# Altium schematic sheet 06_PWR.SchDoc(06_PWR) (continued)

## Library data of the parts
C2 = CAPACITOR: Generic Capacitor; Value: 47nF; Component Kind: Standard; Library Name: Digilent.IntLib
C3 C36 = CAP1_Dup1: CURRENT: CIMAX; DIST: FLAT; KNEE: CBMAX; MAX_TEMP: CTMAX; PSpiceTemplate: C^@REFDES %1 %2 ?TOLERANCE|C^@REFDES| @VALUE ?IC/IC=@IC/ TC=@TC1,@TC2 ?TOLERANCE|\n.model C^@REFDES CAP C=1 DEV=@TOLERANCE% TC1=@TC1 TC2=@TC2 VC1=@VC1 VC2=@VC2|; REUSE_ANNOTATE: TRUE; REUSE_ID: 103; SLOPE: CSMAX; TC1: 0; VOLTAGE: CMAX; Component Kind: Standard; Simulation: CAP1; TC2: 0; VC1: 0; VC2: 0
  C3: Value: 10uF
  C36: Value: 100uF
C5 = CAP1_Dup1: CURRENT: CIMAX; DIST: FLAT; KNEE: CBMAX; MAX_TEMP: CTMAX; PSpiceTemplate: C^@REFDES %1 %2 ?TOLERANCE|C^@REFDES| @VALUE ?IC/IC=@IC/ TC=@TC1,@TC2 ?TOLERANCE|\n.model C^@REFDES CAP C=1 DEV=@TOLERANCE% TC1=@TC1 TC2=@TC2 VC1=@VC1 VC2=@VC2|; REUSE_ANNOTATE: TRUE; REUSE_ID: 343; SLOPE: CSMAX; TC1: 0; VOLTAGE: CMAX; Component Kind: Standard; Simulation: CAP1; TC2: 0; Value: 100nF; VC1: 0; VC2: 0
C6 = CAP1_Dup1: CURRENT: CIMAX; DIST: FLAT; KNEE: CBMAX; MAX_TEMP: CTMAX; PSpiceTemplate: C^@REFDES %1 %2 ?TOLERANCE|C^@REFDES| @VALUE ?IC/IC=@IC/ TC=@TC1,@TC2 ?TOLERANCE|\n.model C^@REFDES CAP C=1 DEV=@TOLERANCE% TC1=@TC1 TC2=@TC2 VC1=@VC1 VC2=@VC2|; REUSE_ANNOTATE: TRUE; REUSE_ID: 344; SLOPE: CSMAX; TC1: 0; VOLTAGE: CMAX; Component Kind: Standard; Simulation: CAP1; TC2: 0; Value: 100nF; VC1: 0; VC2: 0
C7 = CAP1_Dup1: CURRENT: CIMAX; DIST: FLAT; KNEE: CBMAX; MAX_TEMP: CTMAX; PSpiceTemplate: C^@REFDES %1 %2 ?TOLERANCE|C^@REFDES| @VALUE ?IC/IC=@IC/ TC=@TC1,@TC2 ?TOLERANCE|\n.model C^@REFDES CAP C=1 DEV=@TOLERANCE% TC1=@TC1 TC2=@TC2 VC1=@VC1 VC2=@VC2|; REUSE_ANNOTATE: TRUE; REUSE_ID: 106; SLOPE: CSMAX; TC1: 0; VOLTAGE: CMAX; Component Kind: Standard; Simulation: CAP1; TC2: 0; Value: 100nF; VC1: 0; VC2: 0
C8 = CAP1_Dup1: CURRENT: CIMAX; DIST: FLAT; KNEE: CBMAX; MAX_TEMP: CTMAX; PSpiceTemplate: C^@REFDES %1 %2 ?TOLERANCE|C^@REFDES| @VALUE ?IC/IC=@IC/ TC=@TC1,@TC2 ?TOLERANCE|\n.model C^@REFDES CAP C=1 DEV=@TOLERANCE% TC1=@TC1 TC2=@TC2 VC1=@VC1 VC2=@VC2|; REUSE_ANNOTATE: TRUE; REUSE_ID: 107; SLOPE: CSMAX; TC1: 0; VOLTAGE: CMAX; Component Kind: Standard; Simulation: CAP1; TC2: 0; Value: 100nF; VC1: 0; VC2: 0
C9 = CAP1_Dup1: CURRENT: CIMAX; DIST: FLAT; KNEE: CBMAX; MAX_TEMP: CTMAX; PSpiceTemplate: C^@REFDES %1 %2 ?TOLERANCE|C^@REFDES| @VALUE ?IC/IC=@IC/ TC=@TC1,@TC2 ?TOLERANCE|\n.model C^@REFDES CAP C=1 DEV=@TOLERANCE% TC1=@TC1 TC2=@TC2 VC1=@VC1 VC2=@VC2|; REUSE_ANNOTATE: TRUE; REUSE_ID: 108; SLOPE: CSMAX; TC1: 0; VOLTAGE: CMAX; Component Kind: Standard; Simulation: CAP1; TC2: 0; Value: 100nF; VC1: 0; VC2: 0
C10 = CAP1_Dup1: CURRENT: CIMAX; DIST: FLAT; KNEE: CBMAX; MAX_TEMP: CTMAX; PSpiceTemplate: C^@REFDES %1 %2 ?TOLERANCE|C^@REFDES| @VALUE ?IC/IC=@IC/ TC=@TC1,@TC2 ?TOLERANCE|\n.model C^@REFDES CAP C=1 DEV=@TOLERANCE% TC1=@TC1 TC2=@TC2 VC1=@VC1 VC2=@VC2|; REUSE_ANNOTATE: TRUE; REUSE_ID: 109; SLOPE: CSMAX; TC1: 0; VOLTAGE: CMAX; Component Kind: Standard; Simulation: CAP1; TC2: 0; Value: 100nF; VC1: 0; VC2: 0
C11 = CAP1_Dup1: CURRENT: CIMAX; DIST: FLAT; KNEE: CBMAX; MAX_TEMP: CTMAX; PSpiceTemplate: C^@REFDES %1 %2 ?TOLERANCE|C^@REFDES| @VALUE ?IC/IC=@IC/ TC=@TC1,@TC2 ?TOLERANCE|\n.model C^@REFDES CAP C=1 DEV=@TOLERANCE% TC1=@TC1 TC2=@TC2 VC1=@VC1 VC2=@VC2|; REUSE_ANNOTATE: TRUE; REUSE_ID: 111; SLOPE: CSMAX; TC1: 0; VOLTAGE: CMAX; Component Kind: Standard; Simulation: CAP1; TC2: 0; Value: 100nF; VC1: 0; VC2: 0
C12 = CAP1_Dup1: CURRENT: CIMAX; DIST: FLAT; KNEE: CBMAX; MAX_TEMP: CTMAX; PSpiceTemplate: C^@REFDES %1 %2 ?TOLERANCE|C^@REFDES| @VALUE ?IC/IC=@IC/ TC=@TC1,@TC2 ?TOLERANCE|\n.model C^@REFDES CAP C=1 DEV=@TOLERANCE% TC1=@TC1 TC2=@TC2 VC1=@VC1 VC2=@VC2|; REUSE_ANNOTATE: TRUE; REUSE_ID: 112; SLOPE: CSMAX; TC1: 0; VOLTAGE: CMAX; Component Kind: Standard; Simulation: CAP1; TC2: 0; Value: 100nF; VC1: 0; VC2: 0
C13 = CAP1_Dup1: CURRENT: CIMAX; DIST: FLAT; KNEE: CBMAX; MAX_TEMP: CTMAX; PSpiceTemplate: C^@REFDES %1 %2 ?TOLERANCE|C^@REFDES| @VALUE ?IC/IC=@IC/ TC=@TC1,@TC2 ?TOLERANCE|\n.model C^@REFDES CAP C=1 DEV=@TOLERANCE% TC1=@TC1 TC2=@TC2 VC1=@VC1 VC2=@VC2|; REUSE_ANNOTATE: TRUE; REUSE_ID: 110; SLOPE: CSMAX; TC1: 0; VOLTAGE: CMAX; Component Kind: Standard; Simulation: CAP1; TC2: 0; Value: 100nF; VC1: 0; VC2: 0
C14 = CAP1_Dup1: CURRENT: CIMAX; DIST: FLAT; KNEE: CBMAX; MAX_TEMP: CTMAX; PSpiceTemplate: C^@REFDES %1 %2 ?TOLERANCE|C^@REFDES| @VALUE ?IC/IC=@IC/ TC=@TC1,@TC2 ?TOLERANCE|\n.model C^@REFDES CAP C=1 DEV=@TOLERANCE% TC1=@TC1 TC2=@TC2 VC1=@VC1 VC2=@VC2|; REUSE_ANNOTATE: TRUE; REUSE_ID: 113; SLOPE: CSMAX; TC1: 0; VOLTAGE: CMAX; Component Kind: Standard; Simulation: CAP1; TC2: 0; Value: 100nF; VC1: 0; VC2: 0
C15 = CAP1_Dup1: CURRENT: CIMAX; DIST: FLAT; KNEE: CBMAX; MAX_TEMP: CTMAX; PSpiceTemplate: C^@REFDES %1 %2 ?TOLERANCE|C^@REFDES| @VALUE ?IC/IC=@IC/ TC=@TC1,@TC2 ?TOLERANCE|\n.model C^@REFDES CAP C=1 DEV=@TOLERANCE% TC1=@TC1 TC2=@TC2 VC1=@VC1 VC2=@VC2|; REUSE_ANNOTATE: TRUE; REUSE_ID: 340; SLOPE: CSMAX; TC1: 0; VOLTAGE: CMAX; Component Kind: Standard; Simulation: CAP1; TC2: 0; Value: 100nF; VC1: 0; VC2: 0
C16 = CAP1_Dup1: CURRENT: CIMAX; DIST: FLAT; KNEE: CBMAX; MAX_TEMP: CTMAX; PSpiceTemplate: C^@REFDES %1 %2 ?TOLERANCE|C^@REFDES| @VALUE ?IC/IC=@IC/ TC=@TC1,@TC2 ?TOLERANCE|\n.model C^@REFDES CAP C=1 DEV=@TOLERANCE% TC1=@TC1 TC2=@TC2 VC1=@VC1 VC2=@VC2|; REUSE_ANNOTATE: TRUE; REUSE_ID: 341; SLOPE: CSMAX; TC1: 0; VOLTAGE: CMAX; Component Kind: Standard; Simulation: CAP1; TC2: 0; Value: 100nF; VC1: 0; VC2: 0
C18 = CAP1_Dup1: CURRENT: CIMAX; DIST: FLAT; KNEE: CBMAX; MAX_TEMP: CTMAX; PSpiceTemplate: C^@REFDES %1 %2 ?TOLERANCE|C^@REFDES| @VALUE ?IC/IC=@IC/ TC=@TC1,@TC2 ?TOLERANCE|\n.model C^@REFDES CAP C=1 DEV=@TOLERANCE% TC1=@TC1 TC2=@TC2 VC1=@VC1 VC2=@VC2|; REUSE_ANNOTATE: TRUE; REUSE_ID: 342; SLOPE: CSMAX; TC1: 0; VOLTAGE: CMAX; Component Kind: Standard; Simulation: CAP1; TC2: 0; Value: 100nF; VC1: 0; VC2: 0
C19 C150 C151 = CAP1_Dup1: CURRENT: CIMAX; DIST: FLAT; KNEE: CBMAX; MAX_TEMP: CTMAX; PSpiceTemplate: C^@REFDES %1 %2 ?TOLERANCE|C^@REFDES| @VALUE ?IC/IC=@IC/ TC=@TC1,@TC2 ?TOLERANCE|\n.model C^@REFDES CAP C=1 DEV=@TOLERANCE% TC1=@TC1 TC2=@TC2 VC1=@VC1 VC2=@VC2|; REUSE_ANNOTATE: TRUE; REUSE_ID: 339; SLOPE: CSMAX; TC1: 0; VOLTAGE: CMAX; Component Kind: Standard; Simulation: CAP1; TC2: 0; Value: 100nF; VC1: 0; VC2: 0
C20 = CAP1_Dup1: CURRENT: CIMAX; DIST: FLAT; KNEE: CBMAX; MAX_TEMP: CTMAX; PSpiceTemplate: C^@REFDES %1 %2 ?TOLERANCE|C^@REFDES| @VALUE ?IC/IC=@IC/ TC=@TC1,@TC2 ?TOLERANCE|\n.model C^@REFDES CAP C=1 DEV=@TOLERANCE% TC1=@TC1 TC2=@TC2 VC1=@VC1 VC2=@VC2|; REUSE_ANNOTATE: TRUE; REUSE_ID: 116; SLOPE: CSMAX; TC1: 0; VOLTAGE: CMAX; Component Kind: Standard; Simulation: CAP1; TC2: 0; Value: 100nF; VC1: 0; VC2: 0
C21 = CAP1_Dup1: CURRENT: CIMAX; DIST: FLAT; KNEE: CBMAX; MAX_TEMP: CTMAX; PSpiceTemplate: C^@REFDES %1 %2 ?TOLERANCE|C^@REFDES| @VALUE ?IC/IC=@IC/ TC=@TC1,@TC2 ?TOLERANCE|\n.model C^@REFDES CAP C=1 DEV=@TOLERANCE% TC1=@TC1 TC2=@TC2 VC1=@VC1 VC2=@VC2|; REUSE_ANNOTATE: TRUE; REUSE_ID: 119; SLOPE: CSMAX; TC1: 0; VOLTAGE: CMAX; Component Kind: Standard; Simulation: CAP1; TC2: 0; Value: 100nF; VC1: 0; VC2: 0
C22 = CAP1_Dup1: CURRENT: CIMAX; DIST: FLAT; KNEE: CBMAX; MAX_TEMP: CTMAX; PSpiceTemplate: C^@REFDES %1 %2 ?TOLERANCE|C^@REFDES| @VALUE ?IC/IC=@IC/ TC=@TC1,@TC2 ?TOLERANCE|\n.model C^@REFDES CAP C=1 DEV=@TOLERANCE% TC1=@TC1 TC2=@TC2 VC1=@VC1 VC2=@VC2|; REUSE_ANNOTATE: TRUE; REUSE_ID: 122; SLOPE: CSMAX; TC1: 0; VOLTAGE: CMAX; Component Kind: Standard; Simulation: CAP1; TC2: 0; Value: 100nF; VC1: 0; VC2: 0
C23 = CAP1_Dup1: CURRENT: CIMAX; DIST: FLAT; KNEE: CBMAX; MAX_TEMP: CTMAX; PSpiceTemplate: C^@REFDES %1 %2 ?TOLERANCE|C^@REFDES| @VALUE ?IC/IC=@IC/ TC=@TC1,@TC2 ?TOLERANCE|\n.model C^@REFDES CAP C=1 DEV=@TOLERANCE% TC1=@TC1 TC2=@TC2 VC1=@VC1 VC2=@VC2|; REUSE_ANNOTATE: TRUE; REUSE_ID: 118; SLOPE: CSMAX; TC1: 0; VOLTAGE: CMAX; Component Kind: Standard; Simulation: CAP1; TC2: 0; Value: 100nF; VC1: 0; VC2: 0
C24 = CAP1_Dup1: CURRENT: CIMAX; DIST: FLAT; KNEE: CBMAX; MAX_TEMP: CTMAX; PSpiceTemplate: C^@REFDES %1 %2 ?TOLERANCE|C^@REFDES| @VALUE ?IC/IC=@IC/ TC=@TC1,@TC2 ?TOLERANCE|\n.model C^@REFDES CAP C=1 DEV=@TOLERANCE% TC1=@TC1 TC2=@TC2 VC1=@VC1 VC2=@VC2|; REUSE_ANNOTATE: TRUE; REUSE_ID: 120; SLOPE: CSMAX; TC1: 0; VOLTAGE: CMAX; Component Kind: Standard; Simulation: CAP1; TC2: 0; Value: 100nF; VC1: 0; VC2: 0
C25 = CAP1_Dup1: CURRENT: CIMAX; DIST: FLAT; KNEE: CBMAX; MAX_TEMP: CTMAX; PSpiceTemplate: C^@REFDES %1 %2 ?TOLERANCE|C^@REFDES| @VALUE ?IC/IC=@IC/ TC=@TC1,@TC2 ?TOLERANCE|\n.model C^@REFDES CAP C=1 DEV=@TOLERANCE% TC1=@TC1 TC2=@TC2 VC1=@VC1 VC2=@VC2|; REUSE_ANNOTATE: TRUE; REUSE_ID: 124; SLOPE: CSMAX; TC1: 0; VOLTAGE: CMAX; Component Kind: Standard; Simulation: CAP1; TC2: 0; Value: 100nF; VC1: 0; VC2: 0
C26 = CAP1_Dup1: CURRENT: CIMAX; DIST: FLAT; KNEE: CBMAX; MAX_TEMP: CTMAX; PSpiceTemplate: C^@REFDES %1 %2 ?TOLERANCE|C^@REFDES| @VALUE ?IC/IC=@IC/ TC=@TC1,@TC2 ?TOLERANCE|\n.model C^@REFDES CAP C=1 DEV=@TOLERANCE% TC1=@TC1 TC2=@TC2 VC1=@VC1 VC2=@VC2|; REUSE_ANNOTATE: TRUE; REUSE_ID: 114; SLOPE: CSMAX; TC1: 0; VOLTAGE: CMAX; Component Kind: Standard; Simulation: CAP1; TC2: 0; Value: 100nF; VC1: 0; VC2: 0
C27 = CAP1_Dup1: CURRENT: CIMAX; DIST: FLAT; KNEE: CBMAX; MAX_TEMP: CTMAX; PSpiceTemplate: C^@REFDES %1 %2 ?TOLERANCE|C^@REFDES| @VALUE ?IC/IC=@IC/ TC=@TC1,@TC2 ?TOLERANCE|\n.model C^@REFDES CAP C=1 DEV=@TOLERANCE% TC1=@TC1 TC2=@TC2 VC1=@VC1 VC2=@VC2|; REUSE_ANNOTATE: TRUE; REUSE_ID: 125; SLOPE: CSMAX; TC1: 0; VOLTAGE: CMAX; Component Kind: Standard; Simulation: CAP1; TC2: 0; Value: 100nF; VC1: 0; VC2: 0
C28 = CAP1_Dup1: CURRENT: CIMAX; DIST: FLAT; KNEE: CBMAX; MAX_TEMP: CTMAX; PSpiceTemplate: C^@REFDES %1 %2 ?TOLERANCE|C^@REFDES| @VALUE ?IC/IC=@IC/ TC=@TC1,@TC2 ?TOLERANCE|\n.model C^@REFDES CAP C=1 DEV=@TOLERANCE% TC1=@TC1 TC2=@TC2 VC1=@VC1 VC2=@VC2|; REUSE_ANNOTATE: TRUE; REUSE_ID: 117; SLOPE: CSMAX; TC1: 0; VOLTAGE: CMAX; Component Kind: Standard; Simulation: CAP1; TC2: 0; Value: 100nF; VC1: 0; VC2: 0
C29 = CAP1_Dup1: CURRENT: CIMAX; DIST: FLAT; KNEE: CBMAX; MAX_TEMP: CTMAX; PSpiceTemplate: C^@REFDES %1 %2 ?TOLERANCE|C^@REFDES| @VALUE ?IC/IC=@IC/ TC=@TC1,@TC2 ?TOLERANCE|\n.model C^@REFDES CAP C=1 DEV=@TOLERANCE% TC1=@TC1 TC2=@TC2 VC1=@VC1 VC2=@VC2|; REUSE_ANNOTATE: TRUE; REUSE_ID: 129; SLOPE: CSMAX; TC1: 0; VOLTAGE: CMAX; Component Kind: Standard; Simulation: CAP1; TC2: 0; Value: 100nF; VC1: 0; VC2: 0
C30 = CAP1_Dup1: CURRENT: CIMAX; DIST: FLAT; KNEE: CBMAX; MAX_TEMP: CTMAX; PSpiceTemplate: C^@REFDES %1 %2 ?TOLERANCE|C^@REFDES| @VALUE ?IC/IC=@IC/ TC=@TC1,@TC2 ?TOLERANCE|\n.model C^@REFDES CAP C=1 DEV=@TOLERANCE% TC1=@TC1 TC2=@TC2 VC1=@VC1 VC2=@VC2|; REUSE_ANNOTATE: TRUE; REUSE_ID: 126; SLOPE: CSMAX; TC1: 0; VOLTAGE: CMAX; Component Kind: Standard; Simulation: CAP1; TC2: 0; Value: 100nF; VC1: 0; VC2: 0
C31 = CAP1_Dup1: CURRENT: CIMAX; DIST: FLAT; KNEE: CBMAX; MAX_TEMP: CTMAX; PSpiceTemplate: C^@REFDES %1 %2 ?TOLERANCE|C^@REFDES| @VALUE ?IC/IC=@IC/ TC=@TC1,@TC2 ?TOLERANCE|\n.model C^@REFDES CAP C=1 DEV=@TOLERANCE% TC1=@TC1 TC2=@TC2 VC1=@VC1 VC2=@VC2|; REUSE_ANNOTATE: TRUE; REUSE_ID: 121; SLOPE: CSMAX; TC1: 0; VOLTAGE: CMAX; Component Kind: Standard; Simulation: CAP1; TC2: 0; Value: 100nF; VC1: 0; VC2: 0
C32 = CAP1_Dup1: CURRENT: CIMAX; DIST: FLAT; KNEE: CBMAX; MAX_TEMP: CTMAX; PSpiceTemplate: C^@REFDES %1 %2 ?TOLERANCE|C^@REFDES| @VALUE ?IC/IC=@IC/ TC=@TC1,@TC2 ?TOLERANCE|\n.model C^@REFDES CAP C=1 DEV=@TOLERANCE% TC1=@TC1 TC2=@TC2 VC1=@VC1 VC2=@VC2|; REUSE_ANNOTATE: TRUE; REUSE_ID: 128; SLOPE: CSMAX; TC1: 0; VOLTAGE: CMAX; Component Kind: Standard; Simulation: CAP1; TC2: 0; Value: 100nF; VC1: 0; VC2: 0
C33 = CAP1_Dup1: CURRENT: CIMAX; DIST: FLAT; KNEE: CBMAX; MAX_TEMP: CTMAX; PSpiceTemplate: C^@REFDES %1 %2 ?TOLERANCE|C^@REFDES| @VALUE ?IC/IC=@IC/ TC=@TC1,@TC2 ?TOLERANCE|\n.model C^@REFDES CAP C=1 DEV=@TOLERANCE% TC1=@TC1 TC2=@TC2 VC1=@VC1 VC2=@VC2|; REUSE_ANNOTATE: TRUE; REUSE_ID: 127; SLOPE: CSMAX; TC1: 0; VOLTAGE: CMAX; Component Kind: Standard; Simulation: CAP1; TC2: 0; Value: 100nF; VC1: 0; VC2: 0
C34 = CAP1_Dup1: CURRENT: CIMAX; DIST: FLAT; KNEE: CBMAX; MAX_TEMP: CTMAX; PSpiceTemplate: C^@REFDES %1 %2 ?TOLERANCE|C^@REFDES| @VALUE ?IC/IC=@IC/ TC=@TC1,@TC2 ?TOLERANCE|\n.model C^@REFDES CAP C=1 DEV=@TOLERANCE% TC1=@TC1 TC2=@TC2 VC1=@VC1 VC2=@VC2|; REUSE_ANNOTATE: TRUE; REUSE_ID: 123; SLOPE: CSMAX; TC1: 0; VOLTAGE: CMAX; Component Kind: Standard; Simulation: CAP1; TC2: 0; Value: 100nF; VC1: 0; VC2: 0
C35 = CAP1_Dup1: CURRENT: CIMAX; DIST: FLAT; KNEE: CBMAX; MAX_TEMP: CTMAX; PSpiceTemplate: C^@REFDES %1 %2 ?TOLERANCE|C^@REFDES| @VALUE ?IC/IC=@IC/ TC=@TC1,@TC2 ?TOLERANCE|\n.model C^@REFDES CAP C=1 DEV=@TOLERANCE% TC1=@TC1 TC2=@TC2 VC1=@VC1 VC2=@VC2|; REUSE_ANNOTATE: TRUE; REUSE_ID: 115; SLOPE: CSMAX; TC1: 0; VOLTAGE: CMAX; Component Kind: Standard; Simulation: CAP1; TC2: 0; Value: 100nF; VC1: 0; VC2: 0
C37 C87 C91 = CAP1_Dup1: CURRENT: CIMAX; DIST: FLAT; KNEE: CBMAX; MAX_TEMP: CTMAX; PSpiceTemplate: C^@REFDES %1 %2 ?TOLERANCE|C^@REFDES| @VALUE ?IC/IC=@IC/ TC=@TC1,@TC2 ?TOLERANCE|\n.model C^@REFDES CAP C=1 DEV=@TOLERANCE% TC1=@TC1 TC2=@TC2 VC1=@VC1 VC2=@VC2|; REUSE_ANNOTATE: TRUE; REUSE_ID: 336; SLOPE: CSMAX; TC1: 0; VOLTAGE: CMAX; Component Kind: Standard; Simulation: CAP1; TC2: 0; Value: 100nF; VC1: 0; VC2: 0
C38 C88 C92 = CAP1_Dup1: CURRENT: CIMAX; DIST: FLAT; KNEE: CBMAX; MAX_TEMP: CTMAX; PSpiceTemplate: C^@REFDES %1 %2 ?TOLERANCE|C^@REFDES| @VALUE ?IC/IC=@IC/ TC=@TC1,@TC2 ?TOLERANCE|\n.model C^@REFDES CAP C=1 DEV=@TOLERANCE% TC1=@TC1 TC2=@TC2 VC1=@VC1 VC2=@VC2|; REUSE_ANNOTATE: TRUE; REUSE_ID: 335; SLOPE: CSMAX; TC1: 0; VOLTAGE: CMAX; Component Kind: Standard; Simulation: CAP1; TC2: 0; Value: 100nF; VC1: 0; VC2: 0
C39 C89 C93 = CAP1_Dup1: CURRENT: CIMAX; DIST: FLAT; KNEE: CBMAX; MAX_TEMP: CTMAX; PSpiceTemplate: C^@REFDES %1 %2 ?TOLERANCE|C^@REFDES| @VALUE ?IC/IC=@IC/ TC=@TC1,@TC2 ?TOLERANCE|\n.model C^@REFDES CAP C=1 DEV=@TOLERANCE% TC1=@TC1 TC2=@TC2 VC1=@VC1 VC2=@VC2|; REUSE_ANNOTATE: TRUE; REUSE_ID: 338; SLOPE: CSMAX; TC1: 0; VOLTAGE: CMAX; Component Kind: Standard; Simulation: CAP1; TC2: 0; Value: 100nF; VC1: 0; VC2: 0
C40 C90 C94 C152-C154 = CAP1_Dup1: CURRENT: CIMAX; DIST: FLAT; KNEE: CBMAX; MAX_TEMP: CTMAX; PSpiceTemplate: C^@REFDES %1 %2 ?TOLERANCE|C^@REFDES| @VALUE ?IC/IC=@IC/ TC=@TC1,@TC2 ?TOLERANCE|\n.model C^@REFDES CAP C=1 DEV=@TOLERANCE% TC1=@TC1 TC2=@TC2 VC1=@VC1 VC2=@VC2|; REUSE_ANNOTATE: TRUE; REUSE_ID: 337; SLOPE: CSMAX; TC1: 0; VOLTAGE: CMAX; Component Kind: Standard; Simulation: CAP1; TC2: 0; Value: 100nF; VC1: 0; VC2: 0
C41 = CAP1_Dup1: CURRENT: CIMAX; DIST: FLAT; KNEE: CBMAX; MAX_TEMP: CTMAX; PSpiceTemplate: C^@REFDES %1 %2 ?TOLERANCE|C^@REFDES| @VALUE ?IC/IC=@IC/ TC=@TC1,@TC2 ?TOLERANCE|\n.model C^@REFDES CAP C=1 DEV=@TOLERANCE% TC1=@TC1 TC2=@TC2 VC1=@VC1 VC2=@VC2|; REUSE_ANNOTATE: TRUE; REUSE_ID: 144; SLOPE: CSMAX; TC1: 0; VOLTAGE: CMAX; Component Kind: Standard; Simulation: CAP1; TC2: 0; Value: 100nF; VC1: 0; VC2: 0
C42 = CAP1_Dup1: CURRENT: CIMAX; DIST: FLAT; KNEE: CBMAX; MAX_TEMP: CTMAX; PSpiceTemplate: C^@REFDES %1 %2 ?TOLERANCE|C^@REFDES| @VALUE ?IC/IC=@IC/ TC=@TC1,@TC2 ?TOLERANCE|\n.model C^@REFDES CAP C=1 DEV=@TOLERANCE% TC1=@TC1 TC2=@TC2 VC1=@VC1 VC2=@VC2|; REUSE_ANNOTATE: TRUE; REUSE_ID: 139; SLOPE: CSMAX; TC1: 0; VOLTAGE: CMAX; Component Kind: Standard; Simulation: CAP1; TC2: 0; Value: 100nF; VC1: 0; VC2: 0
C43 = CAP1_Dup1: CURRENT: CIMAX; DIST: FLAT; KNEE: CBMAX; MAX_TEMP: CTMAX; PSpiceTemplate: C^@REFDES %1 %2 ?TOLERANCE|C^@REFDES| @VALUE ?IC/IC=@IC/ TC=@TC1,@TC2 ?TOLERANCE|\n.model C^@REFDES CAP C=1 DEV=@TOLERANCE% TC1=@TC1 TC2=@TC2 VC1=@VC1 VC2=@VC2|; REUSE_ANNOTATE: TRUE; REUSE_ID: 140; SLOPE: CSMAX; TC1: 0; VOLTAGE: CMAX; Component Kind: Standard; Simulation: CAP1; TC2: 0; Value: 100nF; VC1: 0; VC2: 0
C44 = CAP1_Dup1: CURRENT: CIMAX; DIST: FLAT; KNEE: CBMAX; MAX_TEMP: CTMAX; PSpiceTemplate: C^@REFDES %1 %2 ?TOLERANCE|C^@REFDES| @VALUE ?IC/IC=@IC/ TC=@TC1,@TC2 ?TOLERANCE|\n.model C^@REFDES CAP C=1 DEV=@TOLERANCE% TC1=@TC1 TC2=@TC2 VC1=@VC1 VC2=@VC2|; REUSE_ANNOTATE: TRUE; REUSE_ID: 131; SLOPE: CSMAX; TC1: 0; VOLTAGE: CMAX; Component Kind: Standard; Simulation: CAP1; TC2: 0; Value: 100nF; VC1: 0; VC2: 0
C45 = CAP1_Dup1: CURRENT: CIMAX; DIST: FLAT; KNEE: CBMAX; MAX_TEMP: CTMAX; PSpiceTemplate: C^@REFDES %1 %2 ?TOLERANCE|C^@REFDES| @VALUE ?IC/IC=@IC/ TC=@TC1,@TC2 ?TOLERANCE|\n.model C^@REFDES CAP C=1 DEV=@TOLERANCE% TC1=@TC1 TC2=@TC2 VC1=@VC1 VC2=@VC2|; REUSE_ANNOTATE: TRUE; REUSE_ID: 135; SLOPE: CSMAX; TC1: 0; VOLTAGE: CMAX; Component Kind: Standard; Simulation: CAP1; TC2: 0; Value: 100nF; VC1: 0; VC2: 0
C46 = CAP1_Dup1: CURRENT: CIMAX; DIST: FLAT; KNEE: CBMAX; MAX_TEMP: CTMAX; PSpiceTemplate: C^@REFDES %1 %2 ?TOLERANCE|C^@REFDES| @VALUE ?IC/IC=@IC/ TC=@TC1,@TC2 ?TOLERANCE|\n.model C^@REFDES CAP C=1 DEV=@TOLERANCE% TC1=@TC1 TC2=@TC2 VC1=@VC1 VC2=@VC2|; REUSE_ANNOTATE: TRUE; REUSE_ID: 141; SLOPE: CSMAX; TC1: 0; VOLTAGE: CMAX; Component Kind: Standard; Simulation: CAP1; TC2: 0; Value: 100nF; VC1: 0; VC2: 0
C47 = CAP1_Dup1: CURRENT: CIMAX; DIST: FLAT; KNEE: CBMAX; MAX_TEMP: CTMAX; PSpiceTemplate: C^@REFDES %1 %2 ?TOLERANCE|C^@REFDES| @VALUE ?IC/IC=@IC/ TC=@TC1,@TC2 ?TOLERANCE|\n.model C^@REFDES CAP C=1 DEV=@TOLERANCE% TC1=@TC1 TC2=@TC2 VC1=@VC1 VC2=@VC2|; REUSE_ANNOTATE: TRUE; REUSE_ID: 133; SLOPE: CSMAX; TC1: 0; VOLTAGE: CMAX; Component Kind: Standard; Simulation: CAP1; TC2: 0; Value: 100nF; VC1: 0; VC2: 0
C48 = CAP1_Dup1: CURRENT: CIMAX; DIST: FLAT; KNEE: CBMAX; MAX_TEMP: CTMAX; PSpiceTemplate: C^@REFDES %1 %2 ?TOLERANCE|C^@REFDES| @VALUE ?IC/IC=@IC/ TC=@TC1,@TC2 ?TOLERANCE|\n.model C^@REFDES CAP C=1 DEV=@TOLERANCE% TC1=@TC1 TC2=@TC2 VC1=@VC1 VC2=@VC2|; REUSE_ANNOTATE: TRUE; REUSE_ID: 132; SLOPE: CSMAX; TC1: 0; VOLTAGE: CMAX; Component Kind: Standard; Simulation: CAP1; TC2: 0; Value: 100nF; VC1: 0; VC2: 0
C49 = CAP1_Dup1: CURRENT: CIMAX; DIST: FLAT; KNEE: CBMAX; MAX_TEMP: CTMAX; PSpiceTemplate: C^@REFDES %1 %2 ?TOLERANCE|C^@REFDES| @VALUE ?IC/IC=@IC/ TC=@TC1,@TC2 ?TOLERANCE|\n.model C^@REFDES CAP C=1 DEV=@TOLERANCE% TC1=@TC1 TC2=@TC2 VC1=@VC1 VC2=@VC2|; REUSE_ANNOTATE: TRUE; REUSE_ID: 143; SLOPE: CSMAX; TC1: 0; VOLTAGE: CMAX; Component Kind: Standard; Simulation: CAP1; TC2: 0; Value: 100nF; VC1: 0; VC2: 0
C50 = CAP1_Dup1: CURRENT: CIMAX; DIST: FLAT; KNEE: CBMAX; MAX_TEMP: CTMAX; PSpiceTemplate: C^@REFDES %1 %2 ?TOLERANCE|C^@REFDES| @VALUE ?IC/IC=@IC/ TC=@TC1,@TC2 ?TOLERANCE|\n.model C^@REFDES CAP C=1 DEV=@TOLERANCE% TC1=@TC1 TC2=@TC2 VC1=@VC1 VC2=@VC2|; REUSE_ANNOTATE: TRUE; REUSE_ID: 145; SLOPE: CSMAX; TC1: 0; VOLTAGE: CMAX; Component Kind: Standard; Simulation: CAP1; TC2: 0; Value: 100nF; VC1: 0; VC2: 0
C51 = CAP1_Dup1: CURRENT: CIMAX; DIST: FLAT; KNEE: CBMAX; MAX_TEMP: CTMAX; PSpiceTemplate: C^@REFDES %1 %2 ?TOLERANCE|C^@REFDES| @VALUE ?IC/IC=@IC/ TC=@TC1,@TC2 ?TOLERANCE|\n.model C^@REFDES CAP C=1 DEV=@TOLERANCE% TC1=@TC1 TC2=@TC2 VC1=@VC1 VC2=@VC2|; REUSE_ANNOTATE: TRUE; REUSE_ID: 130; SLOPE: CSMAX; TC1: 0; VOLTAGE: CMAX; Component Kind: Standard; Simulation: CAP1; TC2: 0; Value: 100nF; VC1: 0; VC2: 0
C52 = CAP1_Dup1: CURRENT: CIMAX; DIST: FLAT; KNEE: CBMAX; MAX_TEMP: CTMAX; PSpiceTemplate: C^@REFDES %1 %2 ?TOLERANCE|C^@REFDES| @VALUE ?IC/IC=@IC/ TC=@TC1,@TC2 ?TOLERANCE|\n.model C^@REFDES CAP C=1 DEV=@TOLERANCE% TC1=@TC1 TC2=@TC2 VC1=@VC1 VC2=@VC2|; REUSE_ANNOTATE: TRUE; REUSE_ID: 134; SLOPE: CSMAX; TC1: 0; VOLTAGE: CMAX; Component Kind: Standard; Simulation: CAP1; TC2: 0; Value: 100nF; VC1: 0; VC2: 0
C53 = CAP1_Dup1: CURRENT: CIMAX; DIST: FLAT; KNEE: CBMAX; MAX_TEMP: CTMAX; PSpiceTemplate: C^@REFDES %1 %2 ?TOLERANCE|C^@REFDES| @VALUE ?IC/IC=@IC/ TC=@TC1,@TC2 ?TOLERANCE|\n.model C^@REFDES CAP C=1 DEV=@TOLERANCE% TC1=@TC1 TC2=@TC2 VC1=@VC1 VC2=@VC2|; REUSE_ANNOTATE: TRUE; REUSE_ID: 334; SLOPE: CSMAX; TC1: 0; VOLTAGE: CMAX; Component Kind: Standard; Simulation: CAP1; TC2: 0; Value: 100nF; VC1: 0; VC2: 0
C54 = CAP1_Dup1: CURRENT: CIMAX; DIST: FLAT; KNEE: CBMAX; MAX_TEMP: CTMAX; PSpiceTemplate: C^@REFDES %1 %2 ?TOLERANCE|C^@REFDES| @VALUE ?IC/IC=@IC/ TC=@TC1,@TC2 ?TOLERANCE|\n.model C^@REFDES CAP C=1 DEV=@TOLERANCE% TC1=@TC1 TC2=@TC2 VC1=@VC1 VC2=@VC2|; REUSE_ANNOTATE: TRUE; REUSE_ID: 332; SLOPE: CSMAX; TC1: 0; VOLTAGE: CMAX; Component Kind: Standard; Simulation: CAP1; TC2: 0; Value: 100nF; VC1: 0; VC2: 0
C55 = CAP1_Dup1: CURRENT: CIMAX; DIST: FLAT; KNEE: CBMAX; MAX_TEMP: CTMAX; PSpiceTemplate: C^@REFDES %1 %2 ?TOLERANCE|C^@REFDES| @VALUE ?IC/IC=@IC/ TC=@TC1,@TC2 ?TOLERANCE|\n.model C^@REFDES CAP C=1 DEV=@TOLERANCE% TC1=@TC1 TC2=@TC2 VC1=@VC1 VC2=@VC2|; REUSE_ANNOTATE: TRUE; REUSE_ID: 331; SLOPE: CSMAX; TC1: 0; VOLTAGE: CMAX; Component Kind: Standard; Simulation: CAP1; TC2: 0; Value: 100nF; VC1: 0; VC2: 0
C56 = CAP1_Dup1: CURRENT: CIMAX; DIST: FLAT; KNEE: CBMAX; MAX_TEMP: CTMAX; PSpiceTemplate: C^@REFDES %1 %2 ?TOLERANCE|C^@REFDES| @VALUE ?IC/IC=@IC/ TC=@TC1,@TC2 ?TOLERANCE|\n.model C^@REFDES CAP C=1 DEV=@TOLERANCE% TC1=@TC1 TC2=@TC2 VC1=@VC1 VC2=@VC2|; REUSE_ANNOTATE: TRUE; REUSE_ID: 333; SLOPE: CSMAX; TC1: 0; VOLTAGE: CMAX; Component Kind: Standard; Simulation: CAP1; TC2: 0; Value: 100nF; VC1: 0; VC2: 0
C57 = CAP1_Dup1: CURRENT: CIMAX; DIST: FLAT; KNEE: CBMAX; MAX_TEMP: CTMAX; PSpiceTemplate: C^@REFDES %1 %2 ?TOLERANCE|C^@REFDES| @VALUE ?IC/IC=@IC/ TC=@TC1,@TC2 ?TOLERANCE|\n.model C^@REFDES CAP C=1 DEV=@TOLERANCE% TC1=@TC1 TC2=@TC2 VC1=@VC1 VC2=@VC2|; REUSE_ANNOTATE: TRUE; REUSE_ID: 319; SLOPE: CSMAX; TC1: 0; VOLTAGE: CMAX; Component Kind: Standard; Simulation: CAP1; TC2: 0; Value: 100nF; VC1: 0; VC2: 0
C58 = CAP1_Dup1: CURRENT: CIMAX; DIST: FLAT; KNEE: CBMAX; MAX_TEMP: CTMAX; PSpiceTemplate: C^@REFDES %1 %2 ?TOLERANCE|C^@REFDES| @VALUE ?IC/IC=@IC/ TC=@TC1,@TC2 ?TOLERANCE|\n.model C^@REFDES CAP C=1 DEV=@TOLERANCE% TC1=@TC1 TC2=@TC2 VC1=@VC1 VC2=@VC2|; REUSE_ANNOTATE: TRUE; REUSE_ID: 329; SLOPE: CSMAX; TC1: 0; VOLTAGE: CMAX; Component Kind: Standard; Simulation: CAP1; TC2: 0; Value: 100nF; VC1: 0; VC2: 0
C59 = CAP1_Dup1: CURRENT: CIMAX; DIST: FLAT; KNEE: CBMAX; MAX_TEMP: CTMAX; PSpiceTemplate: C^@REFDES %1 %2 ?TOLERANCE|C^@REFDES| @VALUE ?IC/IC=@IC/ TC=@TC1,@TC2 ?TOLERANCE|\n.model C^@REFDES CAP C=1 DEV=@TOLERANCE% TC1=@TC1 TC2=@TC2 VC1=@VC1 VC2=@VC2|; REUSE_ANNOTATE: TRUE; REUSE_ID: 318; SLOPE: CSMAX; TC1: 0; VOLTAGE: CMAX; Component Kind: Standard; Simulation: CAP1; TC2: 0; Value: 100nF; VC1: 0; VC2: 0
C60 = CAP1_Dup1: CURRENT: CIMAX; DIST: FLAT; KNEE: CBMAX; MAX_TEMP: CTMAX; PSpiceTemplate: C^@REFDES %1 %2 ?TOLERANCE|C^@REFDES| @VALUE ?IC/IC=@IC/ TC=@TC1,@TC2 ?TOLERANCE|\n.model C^@REFDES CAP C=1 DEV=@TOLERANCE% TC1=@TC1 TC2=@TC2 VC1=@VC1 VC2=@VC2|; REUSE_ANNOTATE: TRUE; REUSE_ID: 317; SLOPE: CSMAX; TC1: 0; VOLTAGE: CMAX; Component Kind: Standard; Simulation: CAP1; TC2: 0; Value: 100nF; VC1: 0; VC2: 0
C61 = CAP1_Dup1: CURRENT: CIMAX; DIST: FLAT; KNEE: CBMAX; MAX_TEMP: CTMAX; PSpiceTemplate: C^@REFDES %1 %2 ?TOLERANCE|C^@REFDES| @VALUE ?IC/IC=@IC/ TC=@TC1,@TC2 ?TOLERANCE|\n.model C^@REFDES CAP C=1 DEV=@TOLERANCE% TC1=@TC1 TC2=@TC2 VC1=@VC1 VC2=@VC2|; REUSE_ANNOTATE: TRUE; REUSE_ID: 316; SLOPE: CSMAX; TC1: 0; VOLTAGE: CMAX; Component Kind: Standard; Simulation: CAP1; TC2: 0; Value: 100nF; VC1: 0; VC2: 0
C62 = CAP1_Dup1: CURRENT: CIMAX; DIST: FLAT; KNEE: CBMAX; MAX_TEMP: CTMAX; PSpiceTemplate: C^@REFDES %1 %2 ?TOLERANCE|C^@REFDES| @VALUE ?IC/IC=@IC/ TC=@TC1,@TC2 ?TOLERANCE|\n.model C^@REFDES CAP C=1 DEV=@TOLERANCE% TC1=@TC1 TC2=@TC2 VC1=@VC1 VC2=@VC2|; REUSE_ANNOTATE: TRUE; REUSE_ID: 323; SLOPE: CSMAX; TC1: 0; VOLTAGE: CMAX; Component Kind: Standard; Simulation: CAP1; TC2: 0; Value: 100nF; VC1: 0; VC2: 0
C63 = CAP1_Dup1: CURRENT: CIMAX; DIST: FLAT; KNEE: CBMAX; MAX_TEMP: CTMAX; PSpiceTemplate: C^@REFDES %1 %2 ?TOLERANCE|C^@REFDES| @VALUE ?IC/IC=@IC/ TC=@TC1,@TC2 ?TOLERANCE|\n.model C^@REFDES CAP C=1 DEV=@TOLERANCE% TC1=@TC1 TC2=@TC2 VC1=@VC1 VC2=@VC2|; REUSE_ANNOTATE: TRUE; REUSE_ID: 326; SLOPE: CSMAX; TC1: 0; VOLTAGE: CMAX; Component Kind: Standard; Simulation: CAP1; TC2: 0; Value: 100nF; VC1: 0; VC2: 0
C64 = CAP1_Dup1: CURRENT: CIMAX; DIST: FLAT; KNEE: CBMAX; MAX_TEMP: CTMAX; PSpiceTemplate: C^@REFDES %1 %2 ?TOLERANCE|C^@REFDES| @VALUE ?IC/IC=@IC/ TC=@TC1,@TC2 ?TOLERANCE|\n.model C^@REFDES CAP C=1 DEV=@TOLERANCE% TC1=@TC1 TC2=@TC2 VC1=@VC1 VC2=@VC2|; REUSE_ANNOTATE: TRUE; REUSE_ID: 328; SLOPE: CSMAX; TC1: 0; VOLTAGE: CMAX; Component Kind: Standard; Simulation: CAP1; TC2: 0; Value: 100nF; VC1: 0; VC2: 0
C65 = CAP1_Dup1: CURRENT: CIMAX; DIST: FLAT; KNEE: CBMAX; MAX_TEMP: CTMAX; PSpiceTemplate: C^@REFDES %1 %2 ?TOLERANCE|C^@REFDES| @VALUE ?IC/IC=@IC/ TC=@TC1,@TC2 ?TOLERANCE|\n.model C^@REFDES CAP C=1 DEV=@TOLERANCE% TC1=@TC1 TC2=@TC2 VC1=@VC1 VC2=@VC2|; REUSE_ANNOTATE: TRUE; REUSE_ID: 321; SLOPE: CSMAX; TC1: 0; VOLTAGE: CMAX; Component Kind: Standard; Simulation: CAP1; TC2: 0; Value: 100nF; VC1: 0; VC2: 0
C66 = CAP1_Dup1: CURRENT: CIMAX; DIST: FLAT; KNEE: CBMAX; MAX_TEMP: CTMAX; PSpiceTemplate: C^@REFDES %1 %2 ?TOLERANCE|C^@REFDES| @VALUE ?IC/IC=@IC/ TC=@TC1,@TC2 ?TOLERANCE|\n.model C^@REFDES CAP C=1 DEV=@TOLERANCE% TC1=@TC1 TC2=@TC2 VC1=@VC1 VC2=@VC2|; REUSE_ANNOTATE: TRUE; REUSE_ID: 320; SLOPE: CSMAX; TC1: 0; VOLTAGE: CMAX; Component Kind: Standard; Simulation: CAP1; TC2: 0; Value: 100nF; VC1: 0; VC2: 0
C67 = CAP1_Dup1: CURRENT: CIMAX; DIST: FLAT; KNEE: CBMAX; MAX_TEMP: CTMAX; PSpiceTemplate: C^@REFDES %1 %2 ?TOLERANCE|C^@REFDES| @VALUE ?IC/IC=@IC/ TC=@TC1,@TC2 ?TOLERANCE|\n.model C^@REFDES CAP C=1 DEV=@TOLERANCE% TC1=@TC1 TC2=@TC2 VC1=@VC1 VC2=@VC2|; REUSE_ANNOTATE: TRUE; REUSE_ID: 315; SLOPE: CSMAX; TC1: 0; VOLTAGE: CMAX; Component Kind: Standard; Simulation: CAP1; TC2: 0; Value: 100nF; VC1: 0; VC2: 0
C68 = CAP1_Dup1: CURRENT: CIMAX; DIST: FLAT; KNEE: CBMAX; MAX_TEMP: CTMAX; PSpiceTemplate: C^@REFDES %1 %2 ?TOLERANCE|C^@REFDES| @VALUE ?IC/IC=@IC/ TC=@TC1,@TC2 ?TOLERANCE|\n.model C^@REFDES CAP C=1 DEV=@TOLERANCE% TC1=@TC1 TC2=@TC2 VC1=@VC1 VC2=@VC2|; REUSE_ANNOTATE: TRUE; REUSE_ID: 330; SLOPE: CSMAX; TC1: 0; VOLTAGE: CMAX; Component Kind: Standard; Simulation: CAP1; TC2: 0; Value: 100nF; VC1: 0; VC2: 0
C69 = CAP1_Dup1: CURRENT: CIMAX; DIST: FLAT; KNEE: CBMAX; MAX_TEMP: CTMAX; PSpiceTemplate: C^@REFDES %1 %2 ?TOLERANCE|C^@REFDES| @VALUE ?IC/IC=@IC/ TC=@TC1,@TC2 ?TOLERANCE|\n.model C^@REFDES CAP C=1 DEV=@TOLERANCE% TC1=@TC1 TC2=@TC2 VC1=@VC1 VC2=@VC2|; REUSE_ANNOTATE: TRUE; REUSE_ID: 325; SLOPE: CSMAX; TC1: 0; VOLTAGE: CMAX; Component Kind: Standard; Simulation: CAP1; TC2: 0; Value: 100nF; VC1: 0; VC2: 0
C70 = CAP1_Dup1: CURRENT: CIMAX; DIST: FLAT; KNEE: CBMAX; MAX_TEMP: CTMAX; PSpiceTemplate: C^@REFDES %1 %2 ?TOLERANCE|C^@REFDES| @VALUE ?IC/IC=@IC/ TC=@TC1,@TC2 ?TOLERANCE|\n.model C^@REFDES CAP C=1 DEV=@TOLERANCE% TC1=@TC1 TC2=@TC2 VC1=@VC1 VC2=@VC2|; REUSE_ANNOTATE: TRUE; REUSE_ID: 173; SLOPE: CSMAX; TC1: 0; VOLTAGE: CMAX; Component Kind: Standard; Simulation: CAP1; TC2: 0; Value: 100nF; VC1: 0; VC2: 0
C71 = CAP1_Dup1: CURRENT: CIMAX; DIST: FLAT; KNEE: CBMAX; MAX_TEMP: CTMAX; PSpiceTemplate: C^@REFDES %1 %2 ?TOLERANCE|C^@REFDES| @VALUE ?IC/IC=@IC/ TC=@TC1,@TC2 ?TOLERANCE|\n.model C^@REFDES CAP C=1 DEV=@TOLERANCE% TC1=@TC1 TC2=@TC2 VC1=@VC1 VC2=@VC2|; REUSE_ANNOTATE: TRUE; REUSE_ID: 171; SLOPE: CSMAX; TC1: 0; VOLTAGE: CMAX; Component Kind: Standard; Simulation: CAP1; TC2: 0; Value: 100nF; VC1: 0; VC2: 0
C72 = CAP1_Dup1: CURRENT: CIMAX; DIST: FLAT; KNEE: CBMAX; MAX_TEMP: CTMAX; PSpiceTemplate: C^@REFDES %1 %2 ?TOLERANCE|C^@REFDES| @VALUE ?IC/IC=@IC/ TC=@TC1,@TC2 ?TOLERANCE|\n.model C^@REFDES CAP C=1 DEV=@TOLERANCE% TC1=@TC1 TC2=@TC2 VC1=@VC1 VC2=@VC2|; REUSE_ANNOTATE: TRUE; REUSE_ID: 172; SLOPE: CSMAX; TC1: 0; VOLTAGE: CMAX; Component Kind: Standard; Simulation: CAP1; TC2: 0; Value: 100nF; VC1: 0; VC2: 0
C73 = CAP1_Dup1: CURRENT: CIMAX; DIST: FLAT; KNEE: CBMAX; MAX_TEMP: CTMAX; PSpiceTemplate: C^@REFDES %1 %2 ?TOLERANCE|C^@REFDES| @VALUE ?IC/IC=@IC/ TC=@TC1,@TC2 ?TOLERANCE|\n.model C^@REFDES CAP C=1 DEV=@TOLERANCE% TC1=@TC1 TC2=@TC2 VC1=@VC1 VC2=@VC2|; REUSE_ANNOTATE: TRUE; REUSE_ID: 169; SLOPE: CSMAX; TC1: 0; VOLTAGE: CMAX; Component Kind: Standard; Simulation: CAP1; TC2: 0; Value: 100nF; VC1: 0; VC2: 0
C74 = CAP1_Dup1: CURRENT: CIMAX; DIST: FLAT; KNEE: CBMAX; MAX_TEMP: CTMAX; PSpiceTemplate: C^@REFDES %1 %2 ?TOLERANCE|C^@REFDES| @VALUE ?IC/IC=@IC/ TC=@TC1,@TC2 ?TOLERANCE|\n.model C^@REFDES CAP C=1 DEV=@TOLERANCE% TC1=@TC1 TC2=@TC2 VC1=@VC1 VC2=@VC2|; REUSE_ANNOTATE: TRUE; REUSE_ID: 157; SLOPE: CSMAX; TC1: 0; VOLTAGE: CMAX; Component Kind: Standard; Simulation: CAP1; TC2: 0; Value: 100nF; VC1: 0; VC2: 0
C75 = CAP1_Dup1: CURRENT: CIMAX; DIST: FLAT; KNEE: CBMAX; MAX_TEMP: CTMAX; PSpiceTemplate: C^@REFDES %1 %2 ?TOLERANCE|C^@REFDES| @VALUE ?IC/IC=@IC/ TC=@TC1,@TC2 ?TOLERANCE|\n.model C^@REFDES CAP C=1 DEV=@TOLERANCE% TC1=@TC1 TC2=@TC2 VC1=@VC1 VC2=@VC2|; REUSE_ANNOTATE: TRUE; REUSE_ID: 152; SLOPE: CSMAX; TC1: 0; VOLTAGE: CMAX; Component Kind: Standard; Simulation: CAP1; TC2: 0; Value: 100nF; VC1: 0; VC2: 0
C76 = CAP1_Dup1: CURRENT: CIMAX; DIST: FLAT; KNEE: CBMAX; MAX_TEMP: CTMAX; PSpiceTemplate: C^@REFDES %1 %2 ?TOLERANCE|C^@REFDES| @VALUE ?IC/IC=@IC/ TC=@TC1,@TC2 ?TOLERANCE|\n.model C^@REFDES CAP C=1 DEV=@TOLERANCE% TC1=@TC1 TC2=@TC2 VC1=@VC1 VC2=@VC2|; REUSE_ANNOTATE: TRUE; REUSE_ID: 160; SLOPE: CSMAX; TC1: 0; VOLTAGE: CMAX; Component Kind: Standard; Simulation: CAP1; TC2: 0; Value: 100nF; VC1: 0; VC2: 0
C77 = CAP1_Dup1: CURRENT: CIMAX; DIST: FLAT; KNEE: CBMAX; MAX_TEMP: CTMAX; PSpiceTemplate: C^@REFDES %1 %2 ?TOLERANCE|C^@REFDES| @VALUE ?IC/IC=@IC/ TC=@TC1,@TC2 ?TOLERANCE|\n.model C^@REFDES CAP C=1 DEV=@TOLERANCE% TC1=@TC1 TC2=@TC2 VC1=@VC1 VC2=@VC2|; REUSE_ANNOTATE: TRUE; REUSE_ID: 151; SLOPE: CSMAX; TC1: 0; VOLTAGE: CMAX; Component Kind: Standard; Simulation: CAP1; TC2: 0; Value: 100nF; VC1: 0; VC2: 0
C78 = CAP1_Dup1: CURRENT: CIMAX; DIST: FLAT; KNEE: CBMAX; MAX_TEMP: CTMAX; PSpiceTemplate: C^@REFDES %1 %2 ?TOLERANCE|C^@REFDES| @VALUE ?IC/IC=@IC/ TC=@TC1,@TC2 ?TOLERANCE|\n.model C^@REFDES CAP C=1 DEV=@TOLERANCE% TC1=@TC1 TC2=@TC2 VC1=@VC1 VC2=@VC2|; REUSE_ANNOTATE: TRUE; REUSE_ID: 153; SLOPE: CSMAX; TC1: 0; VOLTAGE: CMAX; Component Kind: Standard; Simulation: CAP1; TC2: 0; Value: 100nF; VC1: 0; VC2: 0
C79 = CAP1_Dup1: CURRENT: CIMAX; DIST: FLAT; KNEE: CBMAX; MAX_TEMP: CTMAX; PSpiceTemplate: C^@REFDES %1 %2 ?TOLERANCE|C^@REFDES| @VALUE ?IC/IC=@IC/ TC=@TC1,@TC2 ?TOLERANCE|\n.model C^@REFDES CAP C=1 DEV=@TOLERANCE% TC1=@TC1 TC2=@TC2 VC1=@VC1 VC2=@VC2|; REUSE_ANNOTATE: TRUE; REUSE_ID: 175; SLOPE: CSMAX; TC1: 0; VOLTAGE: CMAX; Component Kind: Standard; Simulation: CAP1; TC2: 0; Value: 100nF; VC1: 0; VC2: 0
C80 = CAP1_Dup1: CURRENT: CIMAX; DIST: FLAT; KNEE: CBMAX; MAX_TEMP: CTMAX; PSpiceTemplate: C^@REFDES %1 %2 ?TOLERANCE|C^@REFDES| @VALUE ?IC/IC=@IC/ TC=@TC1,@TC2 ?TOLERANCE|\n.model C^@REFDES CAP C=1 DEV=@TOLERANCE% TC1=@TC1 TC2=@TC2 VC1=@VC1 VC2=@VC2|; REUSE_ANNOTATE: TRUE; REUSE_ID: 178; SLOPE: CSMAX; TC1: 0; VOLTAGE: CMAX; Component Kind: Standard; Simulation: CAP1; TC2: 0; Value: 100nF; VC1: 0; VC2: 0
C81 = CAP1_Dup1: CURRENT: CIMAX; DIST: FLAT; KNEE: CBMAX; MAX_TEMP: CTMAX; PSpiceTemplate: C^@REFDES %1 %2 ?TOLERANCE|C^@REFDES| @VALUE ?IC/IC=@IC/ TC=@TC1,@TC2 ?TOLERANCE|\n.model C^@REFDES CAP C=1 DEV=@TOLERANCE% TC1=@TC1 TC2=@TC2 VC1=@VC1 VC2=@VC2|; REUSE_ANNOTATE: TRUE; REUSE_ID: 177; SLOPE: CSMAX; TC1: 0; VOLTAGE: CMAX; Component Kind: Standard; Simulation: CAP1; TC2: 0; Value: 100nF; VC1: 0; VC2: 0
C95 = Cap3: Capacitor (Semiconductor SIM Model); Value: 0.01UF; Component Kind: Standard; LatestRevisionDate: 29-May-2009; LatestRevisionNote: IPC-7351 Footprint Added.; Library Name: MK7X.IntLib; PackageDescription: Chip Capacitor; PackageReference: C1206; Published: 8-Jun-2000; Signal Integrity: Cap Semi; Simulation: CAP; Publisher: Altium Limited
FB1 FB2 = Inductor: Generic Inductor; Component Kind: Standard; Library Name: MZ7X100.IntLib
R1 = RES1: DIST: FLAT; MAX_TEMP: RTMAX; POWER: RMAX; PSpiceTemplate: R^@REFDES %1 %2 ?TOLERANCE|R^@REFDES| @VALUE TC=@TC1,@TC2 ?TOLERANCE|\n.model R^@REFDES RES R=1 DEV=@TOLERANCE% TC1=@TC1 TC2=@TC2|; REUSE_ANNOTATE: TRUE; REUSE_ID: 95; SLOPE: RSMAX; TC1: 0; VOLTAGE: RVMAX; Component Kind: Standard; Simulation: RES1; TC2: 0; Value: 100R,1%
U1 = XC7K325T-2FFG676I: Kintex-7 FPGA, 500 User I/Os, 8 GTX, 676-Ball BGA, Speed Grade 2, Industrial Grade, Pb-Free; Manufacturer: Xilinx; GTX: 8; SelectIO HP: 150; SelectIO HR: 250; Speed Grade: -2; Code_JEDEC: MS-034-AAL-1; Component Kind: Standard; ComponentLink1Description: Datasheet; ComponentLink1URL: http://www.xilinx.com/support/documentation/data_sheets/ds180_7Series_Overview.pdf; ComponentLink2Description: Package Specification; ComponentLink2URL: http://www.xilinx.com/support/documentation/user_guides/ug865-Zynq-7000-Pkg-Pinout.pdf; DatasheetVersion: v1.12; Generic Part Number: XC7K325T; Library Name: Xilinx Kintex-7.SchLib; Mounting Technology: Surface Mount; PackageDescription: Flip-Chip BGA, Body 27 x 27 mm, 1.0 mm Pitch; PackageReference: FFG676; PackageVersion: Rev 1.1; PartNumber: XC7K325T-2FFG676I; RoHS: Pb-Free
